# Altium schematic sheet Temp_Sense.SchDoc
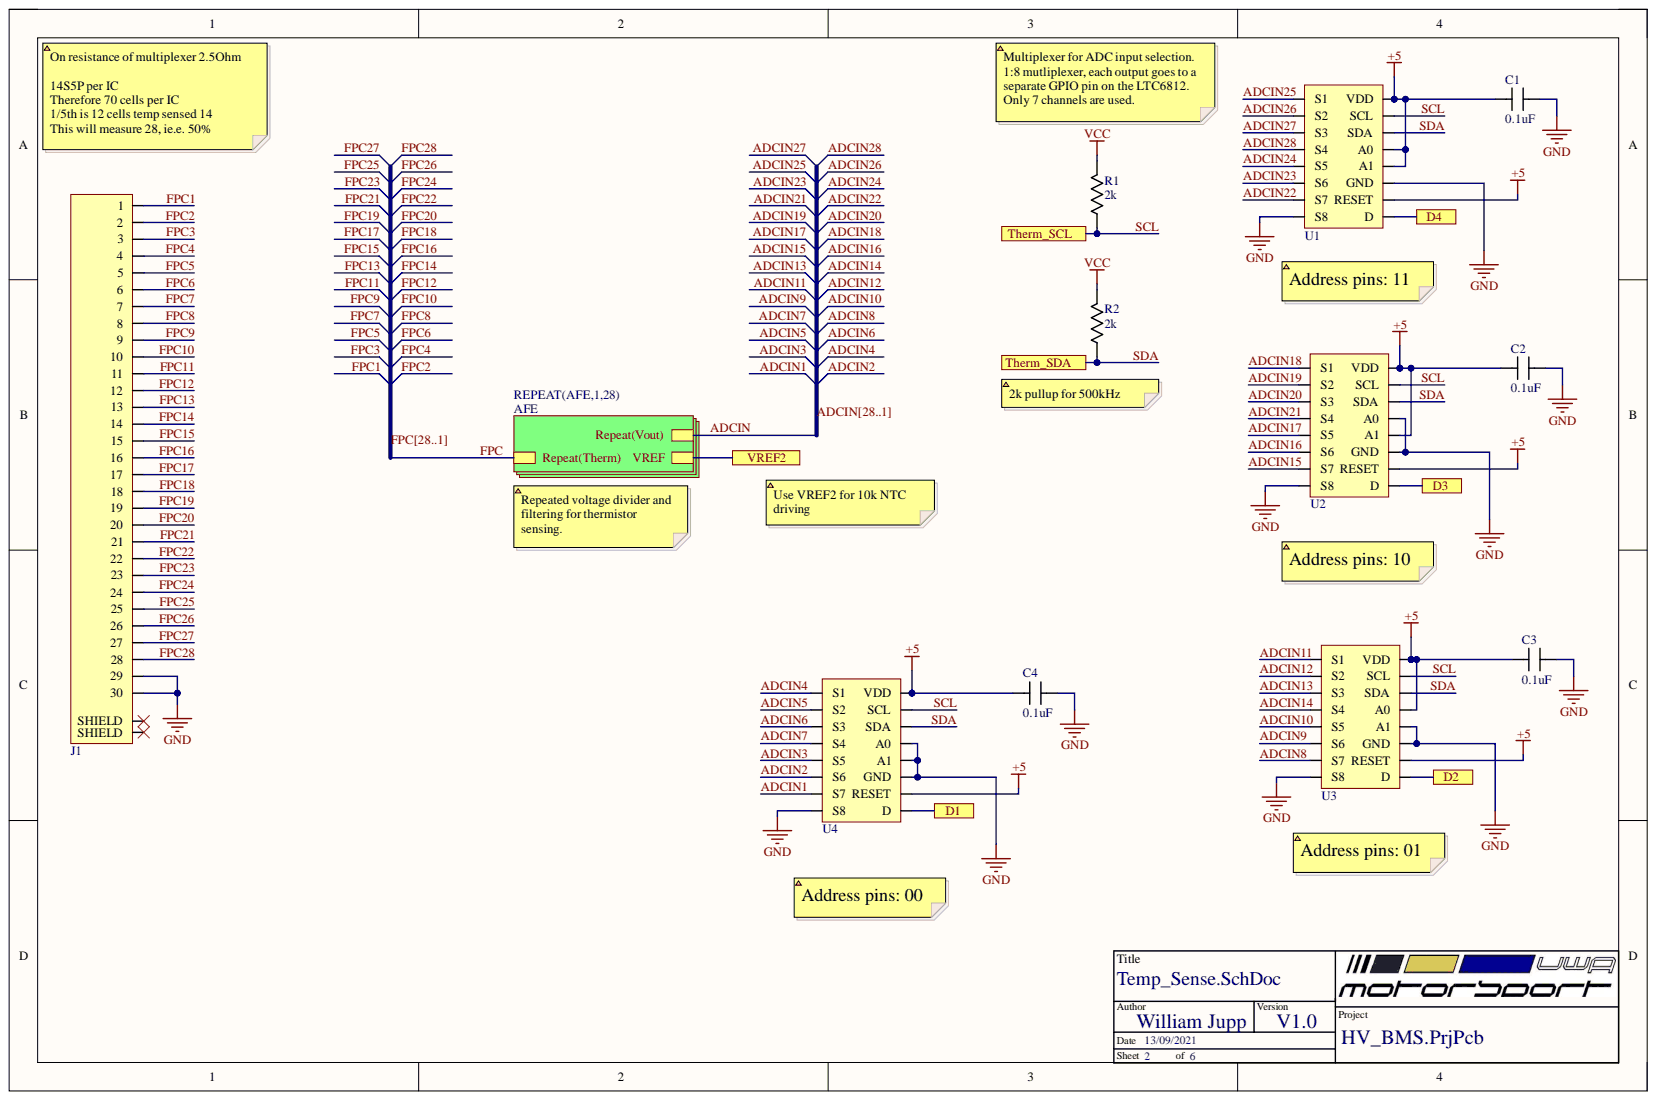
[diagram p0: Temp_Sense.SchDoc]

## Parts
C1  C  value 0.1uF  [C_0603]
C2  C  value 0.1uF  [C_0603]
C3  C  value 0.1uF  [C_0603]
C4  C  value 0.1uF  [C_0603]
J1  3-84953-0  [3-84953-0]  (value not drawn)
R1  value 2k  [R-0603]  (hidden comment: Resistor)
R2  value 2k  [R-0603]  (hidden comment: Resistor)
U1  ADG728  [ADG728]  (value not drawn)
U2  ADG728  [ADG728]  (value not drawn)
U3  ADG728  [ADG728]  (value not drawn)
U4  ADG728  [ADG728]  (value not drawn)

## Ports
D1, D2, D3, D4, Therm_SCL, Therm_SDA, VREF2

## Nets
+5: C1.2 C2.2 C3.2 C4.2 U1.2(RESET) U1.13(VDD) U1.15(A1) U1.16(A0) U2.2(RESET) U2.13(VDD) U2.15(A1) U3.2(RESET) U3.13(VDD) U3.16(A0) U4.2(RESET) U4.13(VDD)
GND: C1.1 C2.1 C3.1 C4.1 J1.29 J1.30 U1.9(S8) U1.14(GND) U2.9(S8) U2.14(GND) U2.16(A0) U3.9(S8) U3.14(GND) U3.15(A1) U4.9(S8) U4.14(GND) U4.15(A1) U4.16(A0)
VCC: R1.2 R2.2
ADCIN1: U4.10(S7)  [also labelled ADCIN]
ADCIN2: U4.11(S6)  [also labelled ADCIN]
ADCIN3: U4.12(S5)  [also labelled ADCIN]
ADCIN4: U4.4(S1)  [also labelled ADCIN]
ADCIN5: U4.5(S2)  [also labelled ADCIN]
ADCIN6: U4.6(S3)  [also labelled ADCIN]
ADCIN7: U4.7(S4)  [also labelled ADCIN]
ADCIN8: U3.10(S7)  [also labelled ADCIN]
ADCIN9: U3.11(S6)  [also labelled ADCIN]
ADCIN10: U3.12(S5)  [also labelled ADCIN]
ADCIN11: U3.4(S1)  [also labelled ADCIN]
ADCIN12: U3.5(S2)  [also labelled ADCIN]
ADCIN13: U3.6(S3)  [also labelled ADCIN]
ADCIN14: U3.7(S4)  [also labelled ADCIN]
ADCIN15: U2.10(S7)  [also labelled ADCIN]
ADCIN16: U2.11(S6)  [also labelled ADCIN]
ADCIN17: U2.12(S5)  [also labelled ADCIN]
ADCIN18: U2.4(S1)  [also labelled ADCIN]
ADCIN19: U2.5(S2)  [also labelled ADCIN]
ADCIN20: U2.6(S3)  [also labelled ADCIN]
ADCIN21: U2.7(S4)  [also labelled ADCIN]
ADCIN22: U1.10(S7)  [also labelled ADCIN]
ADCIN23: U1.11(S6)  [also labelled ADCIN]
ADCIN24: U1.12(S5)  [also labelled ADCIN]
ADCIN25: U1.4(S1)  [also labelled ADCIN]
ADCIN26: U1.5(S2)  [also labelled ADCIN]
ADCIN27: U1.6(S3)  [also labelled ADCIN]
ADCIN28: U1.7(S4)  [also labelled ADCIN]
D1: U4.8(D) ; port D1
D2: U3.8(D) ; port D2
D3: U2.8(D) ; port D3
D4: U1.8(D) ; port D4
FPC1: J1.1  [also labelled FPC]
FPC2: J1.2  [also labelled FPC]
FPC3: J1.3  [also labelled FPC]
FPC4: J1.4  [also labelled FPC]
FPC5: J1.5  [also labelled FPC]
FPC6: J1.6  [also labelled FPC]
FPC7: J1.7  [also labelled FPC]
FPC8: J1.8  [also labelled FPC]
FPC9: J1.9  [also labelled FPC]
FPC10: J1.10  [also labelled FPC]
FPC11: J1.11  [also labelled FPC]
FPC12: J1.12  [also labelled FPC]
FPC13: J1.13  [also labelled FPC]
FPC14: J1.14  [also labelled FPC]
FPC15: J1.15  [also labelled FPC]
FPC16: J1.16  [also labelled FPC]
FPC17: J1.17  [also labelled FPC]
FPC18: J1.18  [also labelled FPC]
FPC19: J1.19  [also labelled FPC]
FPC20: J1.20  [also labelled FPC]
FPC21: J1.21  [also labelled FPC]
FPC22: J1.22  [also labelled FPC]
FPC23: J1.23  [also labelled FPC]
FPC24: J1.24  [also labelled FPC]
FPC25: J1.25  [also labelled FPC]
FPC26: J1.26  [also labelled FPC]
FPC27: J1.27  [also labelled FPC]
FPC28: J1.28  [also labelled FPC]
SCL: R1.1 U1.1(SCL) U2.1(SCL) U3.1(SCL) U4.1(SCL) ; port Therm_SCL
SDA: R2.1 U1.3(SDA) U2.3(SDA) U3.3(SDA) U4.3(SDA) ; port Therm_SDA
VREF: ; port VREF2

Not connected: J1 S1(SHIELD) S2(SHIELD)

## Library data of the parts
C1-C4 = Capacitor: Component Kind: Standard; ComponentLink1Description: New link; Description: Capacitor; Library Name: Passives.IntLib
J1 = 3-84953-0: CONN FPC TOP 30POS 1.00MM R/A; Manufacturer: TE Connectivity; Actuator Color: Black; Actuator Material: Nylon,Glass,Polyamide; Body Plating: Tin; Connector System: Flex-to-Board; Connector Type: Receptacle,Connector,Socket; Contact Current Rating: 1A; Contact Resistance: 10mR; Gender: Female; Insulation: Non-Insulated; Mating Alignment: Yes (With); Max Voltage Rating (AC): 200V; Number of Contacts: 30; PCB Mount Alignment: No (Without); PCB Mount Retention: With; PCB Mounting Orientation: Right Angle; Pin Diameter: 0.2mm; Pitch: 0.039inch; Plating Thickness: 2um; Shielding: Unshielded; Wire/Cable Type: Flat Flex; Category: FFC / FPC; Color: Natural; Component Kind: Standard; ComponentLink1Description: https://datasheet.ciiva.com/11745/0900766b806d28d6-11745122.pdf?src-supplier=RSComponents; ComponentLink1URL: https://datasheet.ciiva.com/11745/0900766b806d28d6-11745122.pdf?src-supplier=RSComponents; ComponentLink2Description: https://datasheet.ciiva.com/1437/eng-cd-84953-a3-1437863.pdf?src-supplier=Element14; ComponentLink2URL: https://datasheet.ciiva.com/1437/eng-cd-84953-a3-1437863.pdf?src-supplier=Element14; ComponentLink3Description: https://datasheet.ciiva.com/1437/eng-cd-84953-a3-1437862.pdf?src-supplier=Element14; ComponentLink3URL: https://datasheet.ciiva.com/1437/eng-cd-84953-a3-1437862.pdf?src-supplier=Element14; ComponentLink4Description: https://datasheet.ciiva.com/5750/586796-5750949.pdf?src-supplier=Farnell; ComponentLink4URL: https://datasheet.ciiva.com/5750/586796-5750949.pdf?src-supplier=Farnell; ComponentLink5Description: https://datasheet.ciiva.com/10034/eng-ds-1-1773440-6-0506-10034394.pdf?src-supplier=Avnet; ComponentLink5URL: https://datasheet.ciiva.com/10034/eng-ds-1-1773440-6-0506-10034394.pdf?src-supplier=Avnet; ComponentLink6Description: https://datasheet.ciiva.com/30052/eng-ds-1-1773440-6-0506-30052600.pdf?src-supplier=Onlinecomponents.com; ComponentLink6URL: https://datasheet.ciiva.com/30052/eng-ds-1-1773440-6-0506-30052600.pdf?src-supplier=Onlinecomponents.com; ComponentLink7Description: https://datasheet.ciiva.com/669/eng-cd-84953-a3-669167.pdf?src-supplier=Digi-Key; ComponentLink7URL: https://datasheet.ciiva.com/669/eng-cd-84953-a3-669167.pdf?src-supplier=Digi-Key; ComponentLink8Description: https://datasheet.ciiva.com/669/eng-cd-84953-a3-669168.pdf?src-supplier=Digi-Key; ComponentLink8URL: https://datasheet.ciiva.com/669/eng-cd-84953-a3-669168.pdf?src-supplier=Digi-Key; ComponentLink9Description: https://datasheet.ciiva.com/669/eng-cd-84953-a3-669166.pdf?src-supplier=Digi-Key; ComponentLink9URL: https://datasheet.ciiva.com/669/eng-cd-84953-a3-669166.pdf?src-supplier=Digi-Key; Contact Location: Top; Contact Material: Bronze; Contact Plating: Tin,Bright; Current Rating: 1A; Depth: 7.3mm; ELV: Compliant; Flammability Rating: UL94 V-0; Height: 2.56mm; Housing Color: Natural; Housing Material: Polymer; Lead Pitch: 1mm; Length: 37.92mm; Library Name: TE_Con.IntLib; Manufacturer Part Number: 3-84953-0; Material: Thermoplastic; Max Operating Temperature: 85°C; Min Operating Temperature: -40°C; Mount: Surface Mount,Through Hole; Number of Positions: 30; Number of Rows: 1; Orientation: Right Angle; Package Quantity: 1200; Packaging: Tape and Reel; Pricing 1: 1=1.65000, 10=1.41000, 50=1.41000, 100=1.22000, 500=1.07000, 1000=1.07000, 1200=0.91500, 2400=0.87100, 10000=0.87100 (USD); Radiation Hardening: No; REACH SVHC: No SVHC; RoHS: Yes; Sealable: No (Without); Series: -; Shrouded: Yes (With); Stock 1: 14380; Supplier 1: Mouser; Supplier Part Number 1: 571-3-84953-0; Termination: SMD/SMT; Voltage Rating: 200V; Width: 0.2575inch
U1-U4 = ADG728: Analog Multiplexer Single 8:1 16-Pin TSSOP Tube; Manufacturer: Analog Devices; Manufacturer Part Number: ADG728BRUZ; -3db Bandwidth: 65MHz; Drain to Source Resistance: 2.5R; High Level Output Current: 120mA; Interface: Serial,2-Wire; Max Power Dissipation: 100uW; Max Supply Current: 20uA; Max Supply Voltage: 5.5V; Min Supply Voltage: 2.7V; Number of Bits: 8; Number of Channels: 1; On-State Resistance: 4.5R; Operating Supply Current: 10uA; Throw Configuration: DPST; Turn-Off Delay Time: 85ns; Turn-On Delay Time: 95ns; Bandwidth: 65MHz; Case/Package: TSSOP; Category: Decoders and Multiplexers; Component Kind: Standard; ComponentLink1Description: https://datasheet.ciiva.com/1171/1610644-1171108.pdf?src-supplier=Element14; ComponentLink1URL: https://datasheet.ciiva.com/1171/1610644-1171108.pdf?src-supplier=Element14; ComponentLink2Description: https://datasheet.ciiva.com/5797/adg728-729-5797207.pdf?src-supplier=Farnell; ComponentLink2URL: https://datasheet.ciiva.com/5797/adg728-729-5797207.pdf?src-supplier=Farnell; ComponentLink3Description: https://datasheet.ciiva.com/1668/adg728-729-1668904.pdf?src-supplier=Digi-Key; ComponentLink3URL: https://datasheet.ciiva.com/1668/adg728-729-1668904.pdf?src-supplier=Digi-Key; ComponentLink4Description: https://datasheet.ciiva.com/2978/adg728-729-2978667.pdf?src-supplier=Avnet; ComponentLink4URL: https://datasheet.ciiva.com/2978/adg728-729-2978667.pdf?src-supplier=Avnet; ComponentLink5Description: https://datasheet.ciiva.com/7986/adg728-729-7986413.pdf?src-supplier=Element14; ComponentLink5URL: https://datasheet.ciiva.com/7986/adg728-729-7986413.pdf?src-supplier=Element14; ComponentLink6Description: https://datasheet.ciiva.com/48708/001248036-da-01-en-ic-mux-demux-8x1-adg728bruz-tssop-16-adi-48708636.pdf?src-supplier=Conrad; ComponentLink6URL: https://datasheet.ciiva.com/48708/001248036-da-01-en-ic-mux-demux-8x1-adg728bruz-tssop-16-adi-48708636.pdf?src-supplier=Conrad; Contact Plating: Tin,Matte; Height: 1.05mm; Lead Free: Contains Lead; Length: 5mm; Library Name: HV_BMS_SCH_LIB.SchLib; Max Operating Temperature: 85°C; Min Operating Temperature: -40°C; Nominal Supply Current: 20uA; Number of Circuits: 1; Number of Inputs: 8; Number of Outputs: 1; Number of Pins: 16; Package Quantity: 96; Packaging: Rail/Tube; Pricing 1: 1=6.74000, 10=6.06000, 50=5.73000, 100=4.96000, 1000=3.59000, 10000=3.59000 (USD); Radiation Hardening: No; REACH SVHC: No SVHC; RoHS: Yes; RoHS Compliant: Yes; Series: -; Stock 1: 392; Supplier 1: Mouser; Supplier Part Number 1: 584-ADG728BRUZ; Supply Current: 20uA; Width: 4.4mm
R1 R2 = Resistor: Component Kind: Standard; Description: Resistor; Library Name: Passives.IntLib
